# Altium schematic sheet WIFI点火.SchDoc
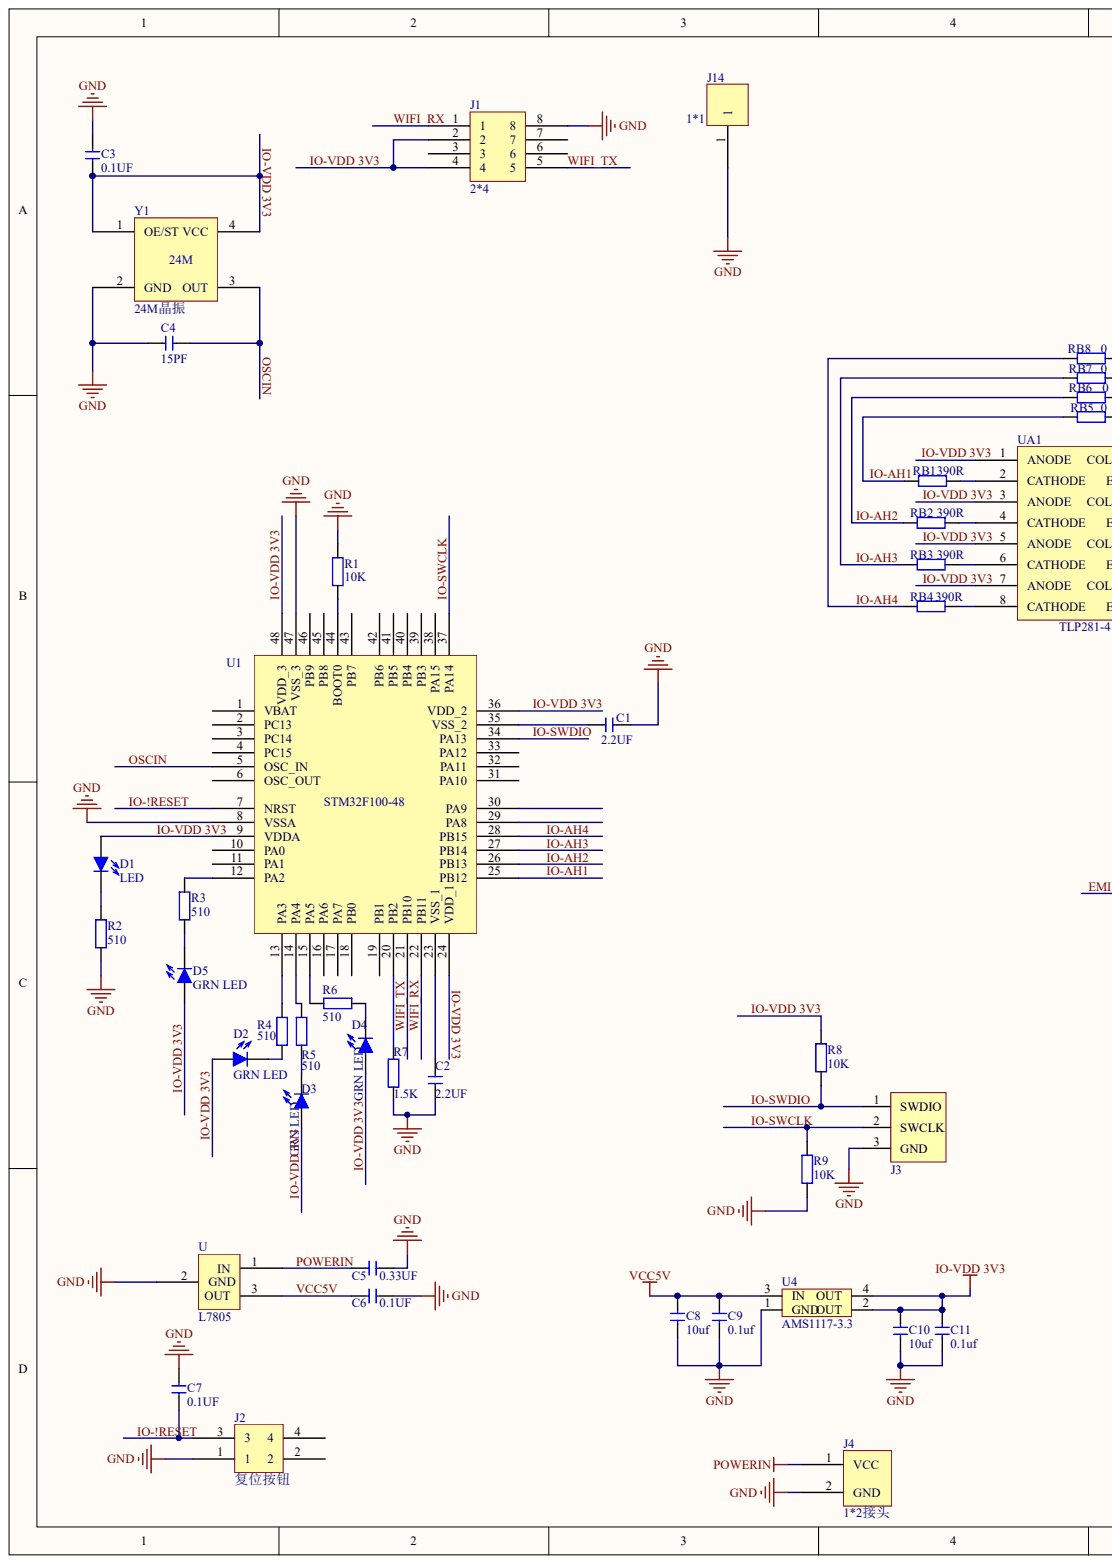
[diagram p0: WIFI点火.SchDoc, left]
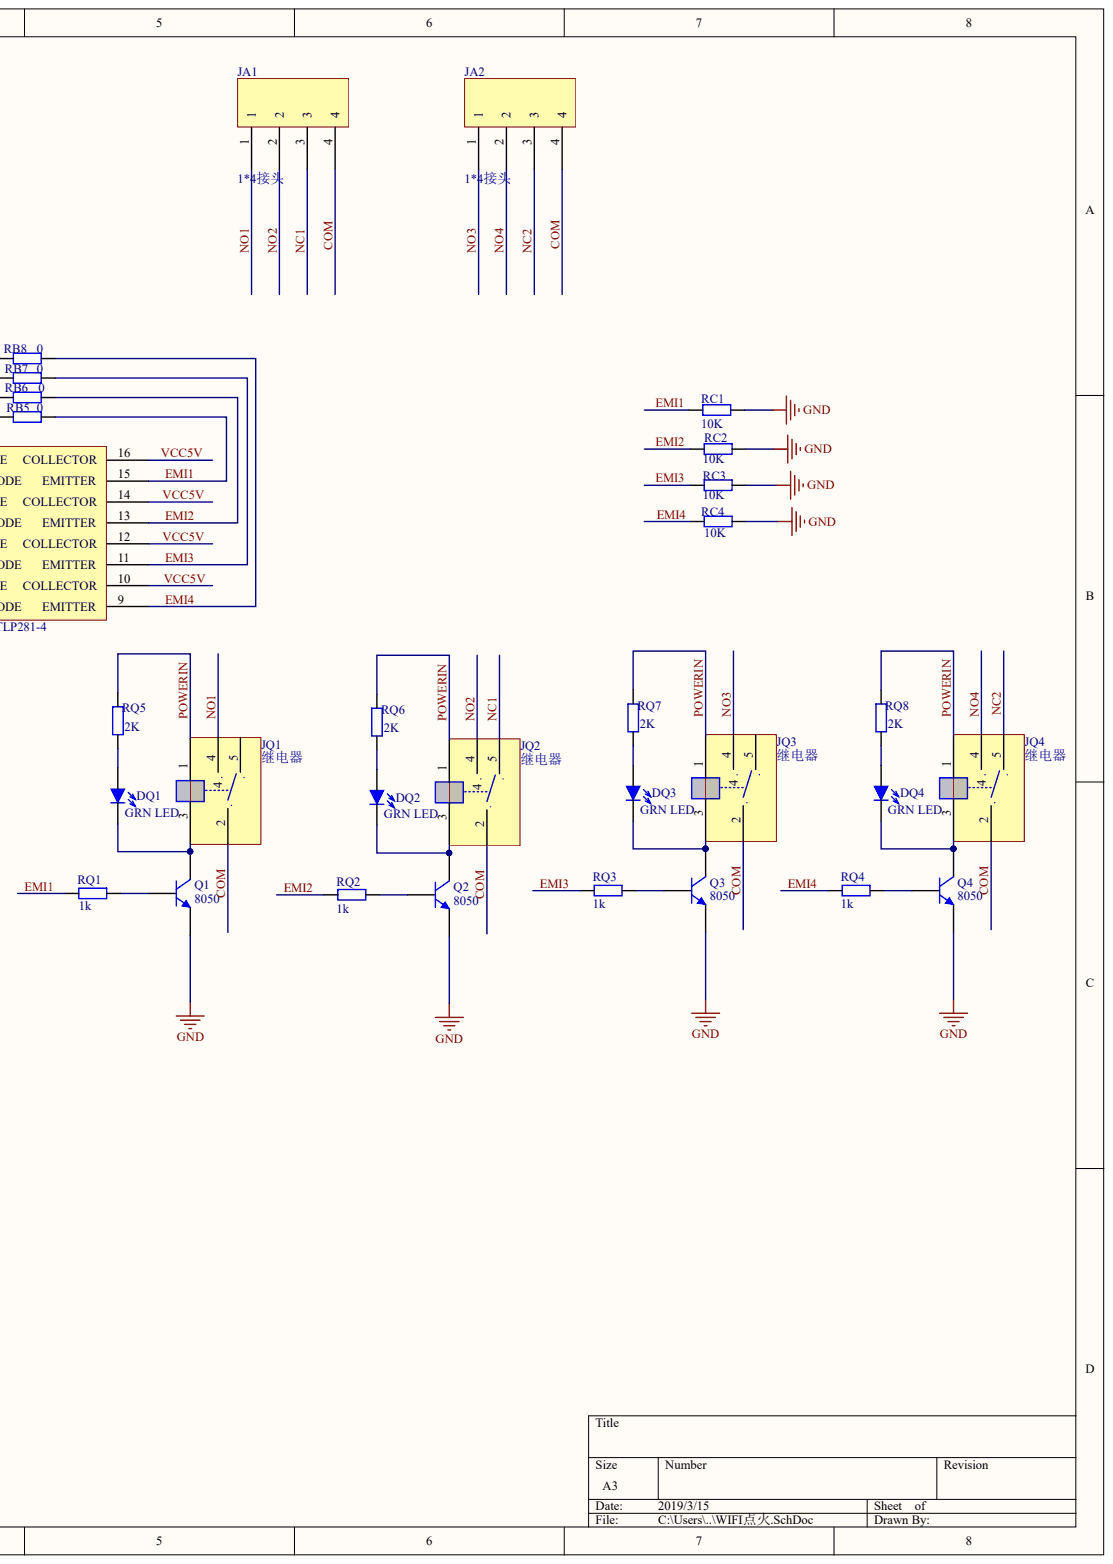
[diagram p1: WIFI点火.SchDoc, right]

## Parts
C1  2.2UF
C2  2.2UF
C3  0.1UF
C4  15PF
C5  0.33UF
C6  0.1UF
C7  0.1UF
C8  10uf
C9  0.1uf
C10  10uf
C11  0.1uf
D1  LED
D2  GRN LED
D3  GRN LED
D4  GRN LED
D5  GRN LED
DQ1  GRN LED
DQ2  GRN LED
DQ3  GRN LED
DQ4  GRN LED
J1  2*4
J2  复位按钮
J3  烧写触点  (value not drawn)
J4  1*2接头
J14  1*1
JA1  1*4接头
JA2  1*4接头
JQ1  继电器
JQ2  继电器
JQ3  继电器
JQ4  继电器
Q1  8050
Q2  8050
Q3  8050
Q4  8050
R1  10K
R2  510
R3  510
R4  510
R5  510
R6  510
R7  1.5K
R8  10K
R9  10K
RB1  390R
RB2  390R
RB3  390R
RB4  390R
RB5  0
RB6  0
RB7  0
RB8  0
RC1  10K
RC2  10K
RC3  10K
RC4  10K
RQ1  1k
RQ2  1k
RQ3  1k
RQ4  1k
RQ5  2K
RQ6  2K
RQ7  2K
RQ8  2K
U  L7805
U1  STM32F100-48
U4  AMS1117-3.3
UA1  TLP281-4
Y1  24M晶振

## Nets
GND: C1.2 C2.1 C3.2 C4.1 C5.2 C6.2 C7.2 C8.1 C9.1 C10.1 C11.1 J1.8 J2.1 J3.3 J4.2 J14.1 Q1.1 Q2.1 Q3.1 Q4.1 R1.2 R2.1 R7.1 R9.1 RC1.2 RC2.2 RC3.2 RC4.2 U.2 U1.8(VSSA) U1.47(VSS_3) U4.1(GND) Y1.2(GND)
COM: JA1.4 JA2.4 JQ1.2 JQ2.2 JQ3.2 JQ4.2
EMI1: RB5.2 RC1.1 RQ1.1 UA1.15(EMITTER)
EMI2: RB6.2 RC2.1 RQ2.1 UA1.13(EMITTER)
EMI3: RB7.2 RC3.1 RQ3.1 UA1.11(EMITTER)
EMI4: RB8.2 RC4.1 RQ4.1 UA1.9(EMITTER)
IO-!RESET: C7.1 J2.3 U1.7(NRST)
IO-AH1: RB1.1 RB5.1 U1.25(PB12)
IO-AH2: RB2.1 RB6.1 U1.26(PB13)
IO-AH3: RB3.1 RB7.1 U1.27(PB14)
IO-AH4: RB4.1 RB8.1 U1.28(PB15)
IO-SWCLK: J3.2 R9.2 U1.37(PA14)
IO-SWDIO: J3.1 R8.1 U1.34(PA13)
IO-VDD 3V3: C3.1 C10.2 C11.2 D1.1 D2.1 D3.1 D4.1 D5.1 J1.2 J1.4 R8.2 U1.9(VDDA) U1.24(VDD_1) U1.36(VDD_2) U1.48(VDD_3) U4.2(OUT) U4.4(OUT) UA1.1(ANODE) UA1.3(ANODE) UA1.5(ANODE) UA1.7(ANODE) Y1.1(OE/ST) Y1.4(VCC)
NC1: JA1.3 JQ2.5
NC2: JA2.3 JQ4.5
NO1: JA1.1 JQ1.4
NO2: JA1.2 JQ2.4
NO3: JA2.1 JQ3.4
NO4: JA2.2 JQ4.4
OSCIN: C4.2 U1.5(OSC_IN) Y1.3(OUT)
POWERIN: C5.1 J4.1 JQ1.1 JQ2.1 JQ3.1 JQ4.1 RQ5.2 RQ6.2 RQ7.2 RQ8.2 U.1
VCC5V: C6.1 C8.2 C9.2 U.3 U4.3(IN) UA1.10(COLLECTOR) UA1.12(COLLECTOR) UA1.14(COLLECTOR) UA1.16(COLLECTOR)
WIFI_RX: J1.1 U1.22(PB11)
WIFI_TX: J1.5 U1.21(PB10)
NetC1_1: C1.1 U1.35(VSS_2)
NetC2_2: C2.2 U1.23(VSS_1)
NetD1_2: D1.2 R2.2
NetD2_2: D2.2 R4.1
NetD3_2: D3.2 R5.1
NetD4_2: D4.2 R6.2
NetD5_2: D5.2 R3.1
NetDQ1_1: DQ1.1 RQ5.1
NetDQ1_2: DQ1.2 JQ1.3 Q1.3
NetDQ2_1: DQ2.1 RQ6.1
NetDQ2_2: DQ2.2 JQ2.3 Q2.3
NetDQ3_1: DQ3.1 RQ7.1
NetDQ3_2: DQ3.2 JQ3.3 Q3.3
NetDQ4_1: DQ4.1 RQ8.1
NetDQ4_2: DQ4.2 JQ4.3 Q4.3
NetQ1_2: Q1.2 RQ1.2
NetQ2_2: Q2.2 RQ2.2
NetQ3_2: Q3.2 RQ3.2
NetQ4_2: Q4.2 RQ4.2
NetR1_1: R1.1 U1.44(BOOT0)
NetR3_2: R3.2 U1.12(PA2)
NetR4_2: R4.2 U1.13(PA3)
NetR5_2: R5.2 U1.14(PA4)
NetR6_1: R6.1 U1.15(PA5)
NetR7_2: R7.2 U1.20(PB2)
NetRB1_2: RB1.2 UA1.2(CATHODE)
NetRB2_2: RB2.2 UA1.4(CATHODE)
NetRB3_2: RB3.2 UA1.6(CATHODE)
NetRB4_2: RB4.2 UA1.8(CATHODE)

Not connected: J1 3 6 7; J2 2 4; JQ1 5; JQ3 5; U1 1(VBAT) 2(PC13) 3(PC14) 4(PC15) 6(OSC_OUT) 10(PA0) 11(PA1) 16(PA6) 17(PA7) 18(PB0) 19(PB1) 29(PA8) 30(PA9) 31(PA10) 32(PA11) 33(PA12) 38(PA15) 39(PB3) 40(PB4) 41(PB5) 42(PB6) 43(PB7) 45(PB8) 46(PB9)

## Library data of the parts
C1-C11 D1-D5 DQ1-DQ4 J1-J4 J14 JA1 JA2 JQ1-JQ4 Q1-Q4 R1-R9 RB1-RB8 RC1-RC4 RQ1-RQ8 U U1 U4 UA1 Y1 = (no symbol name)
  Q1-Q4: Published: 8-Jun-2000; LatestRevisionDate: 15-Jan-2003; LatestRevisionNote: PCB Footprint 'BCY-W3/D4.7' replaced; DatasheetVersion: 1997; PackageVersion: Sep-1998; PackageReference: TO-92A; PackageDescription: TO, Flat Index; 3 In-Line, Axial Leads; Body Dia. 4.5mm; Leads 0.51 x 0.48 mm (max); ComponentLink1Description: Manufacturer Link; ComponentLink1URL: http://www.fairchildsemi.com/; ComponentLink2Description: Datasheet; ComponentLink2URL: http://www.fairchildsemi.com/ds/2N%2F2N3904.pdf; Publisher: Altium Limited
